# Altium schematic sheet I2C Expanders.SchDoc("I2C Expanders")
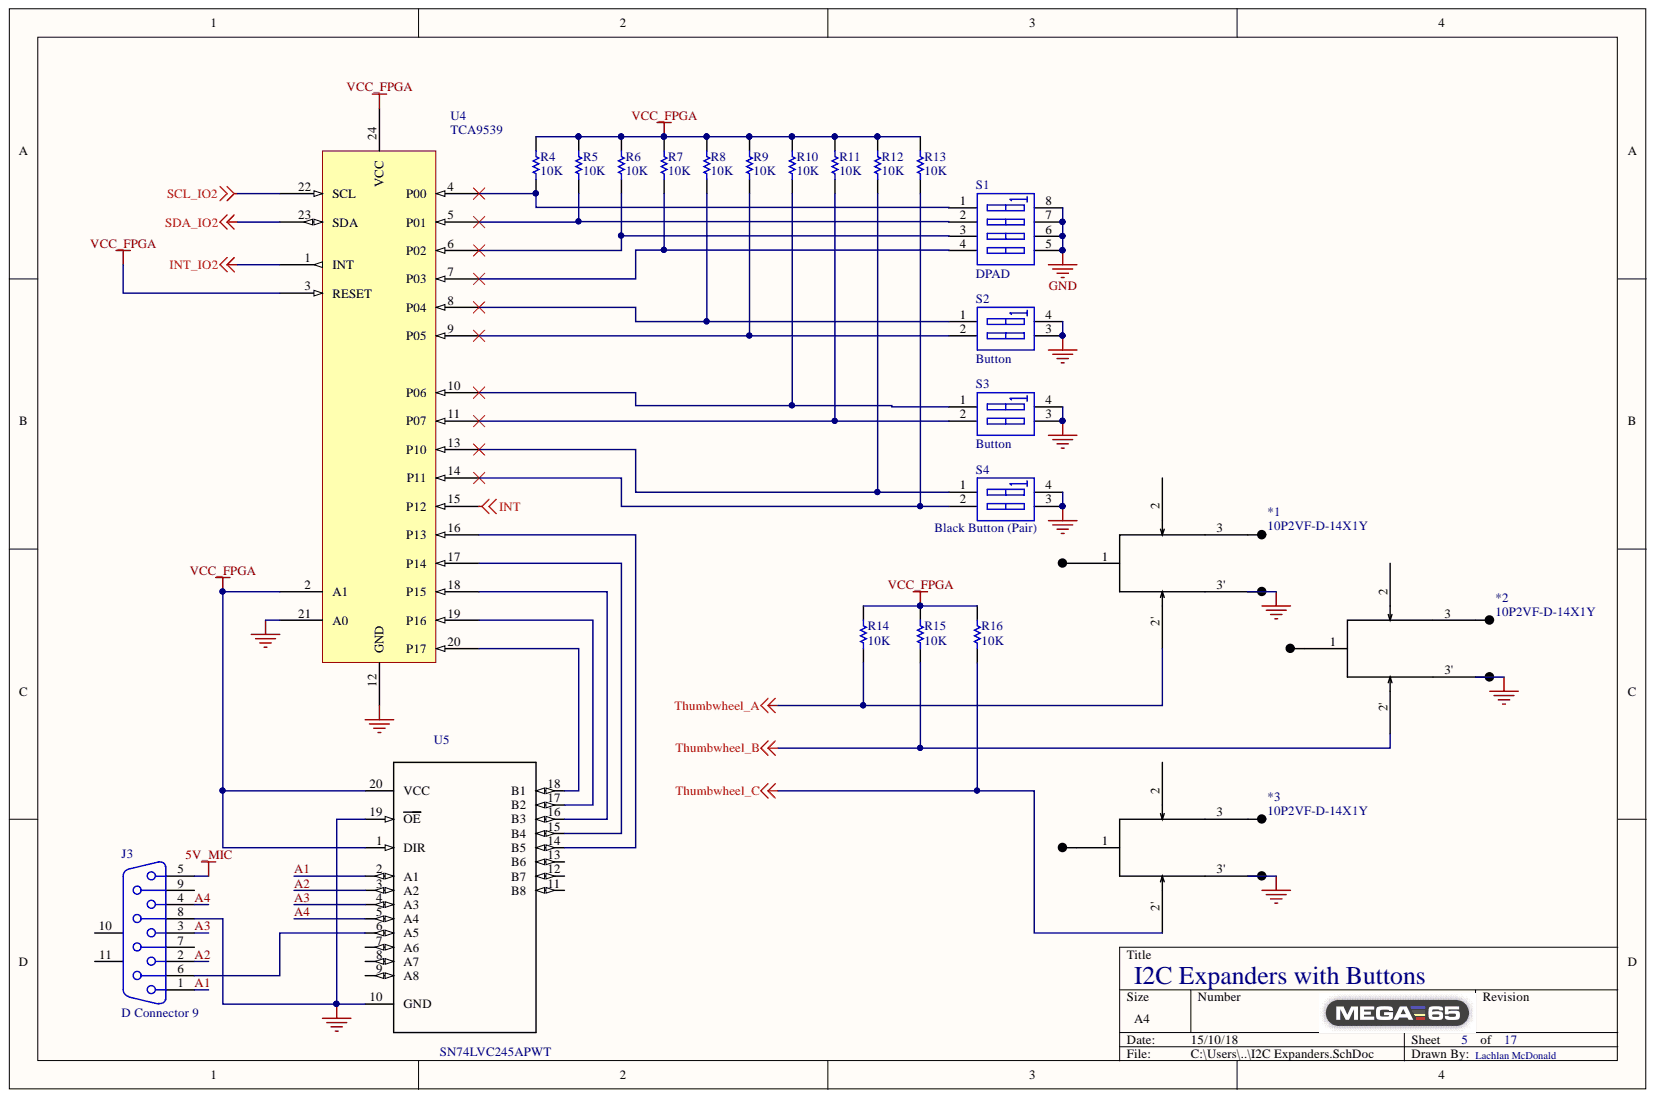
[diagram p0: I2C Expanders.SchDoc]

## Parts
*1  10P2VF-D-14X1Y  [10P2VF-D-141Y]
*2  10P2VF-D-14X1Y  [10P2VF-D-141Y]
*3  10P2VF-D-14X1Y  [10P2VF-D-141Y]
J3  D Connector 9  [DB9 Connector]
R4  10K  [RESC0805(2012)_M]
R5  10K  [RESC0805(2012)_M]
R6  10K  [RESC0805(2012)_M]
R7  10K  [RESC0805(2012)_M]
R8  10K  [RESC0805(2012)_M]
R9  10K  [RESC0805(2012)_M]
R10  10K  [RESC0805(2012)_M]
R11  10K  [RESC0805(2012)_M]
R12  10K  [RESC0805(2012)_M]
R13  10K  [RESC0805(2012)_M]
R14  10K  [RESC0805(2012)_M]
R15  10K  [RESC0805(2012)_M]
R16  10K  [RESC0805(2012)_M]
S1  DPAD  [DPAD]
S2  Button  [Button]
S3  Button  [Button]
S4  Black Button (Pair)  [Black Button]
U4  TCA9539  [PW24]
U5  SN74LVC245APWT  [SOP65P640X120-20N]

## Nets
5V_MIC: J3.5
GND: *1.3' *2.3' *3.3' J3.8 S1.5 S1.6 S1.7 S1.8 S2.3 S2.4 S3.3 S3.4 S4.3 S4.4 U4.12(GND) U4.21(A0) U5.10(GND) U5.19(OE)
INT: U4.15(P12)
INT_IO2: U4.1(INT)
SCL_IO2: U4.22(SCL)
SDA_IO2: U4.23(SDA)
Thumbwheel_A: *1.2' R14.2
Thumbwheel_B: *2.2' R15.2
Thumbwheel_C: *3.2' R16.2
VCC_FPGA: R4.1 R5.1 R6.1 R7.1 R8.1 R9.1 R10.1 R11.1 R12.1 R13.1 R14.1 R15.1 R16.1 U4.2(A1) U4.3(RESET) U4.24(VCC) U5.1(DIR) U5.20(VCC)
A1: J3.1 U5.2(A1)
A2: J3.2 U5.3(A2)
A3: J3.3 U5.4(A3)
A4: J3.4 U5.5(A4)
NetJ3_6: J3.6 U5.6(A5)
NetR4_2: R4.2 S1.1 U4.4(P00)
NetR5_2: R5.2 S1.2 U4.5(P01)
NetR6_2: R6.2 S1.3 U4.6(P02)
NetR7_2: R7.2 S1.4 U4.7(P03)
NetR8_2: R8.2 S2.1 U4.8(P04)
NetR9_2: R9.2 S2.2 U4.9(P05)
NetR10_2: R10.2 S3.1 U4.10(P06)
NetR11_2: R11.2 S3.2 U4.11(P07)
NetR12_2: R12.2 S4.1 U4.13(P10)
NetR13_2: R13.2 S4.2 U4.14(P11)
NetU4_16: U4.16(P13) U5.14(B5)
NetU4_17: U4.17(P14) U5.15(B4)
NetU4_18: U4.18(P15) U5.16(B3)
NetU4_19: U4.19(P16) U5.17(B2)
NetU4_20: U4.20(P17) U5.18(B1)

Not connected: *1 1 2 3; *2 1 2 3; *3 1 2 3; J3 7 9 10 11; U5 7(A6) 8(A7) 9(A8) 11(B8) 12(B7) 13(B6)

## Library data of the parts
J3 = D Connector 9: Receptacle Assembly, 9 Position, Right Angle; Positions: 9; PackageDocument: O; Signal Integrity: Connector; Published: 15-Jun-2001; PackageReference: 788750; Orientation: Right Angle; Library Name: Miscellaneous Connectors.IntLib; LatestRevisionNote: Re-released for DXP Platform.; LatestRevisionDate: 17-Jul-2002; Gender: Receptacle; DatasheetDocument: 1-Sep-1999; Component Kind: Standard; Publisher: Altium Limited
R4-R16 = CMP-1013-00623-1: 10K 0.125W 1% 0805 (2012 Metric)  SMD; Value: 10000.00 Ohm; Case-Metric: 2012; Case-EIA: 0805; Tolerance: 1 %; Technology: SMT; Power: 0.125 W; Library Name: Altium Content Vault; Component Kind: Standard
S1 = SW DIP-4: DIP Switch, 4 Position, SPST; Simulation: dpsw4; Published: 24-Mar-1999; PackageVersion: Dec-2002; PackageReference: SO8; PackageDescription: 8-Pin Small Outline Integrated Circuit 1.27 mm Pitch; Library Name: Miscellaneous Devices.IntLib; LatestRevisionNote: IPC-7351 Footprint Added.; LatestRevisionDate: 29-May-2009; Component Kind: Standard; Code_JEDEC: MS-012-AA; Code_IPC: SOIC127P600-8; Publisher: Altium Limited
S2-S4 = SW DIP-2: DIP Switch, 2 Position, SPST; Simulation: dpsw2; Published: 24-Mar-1999; PackageReference: DIP-4; Library Name: Miscellaneous Devices.IntLib; LatestRevisionNote: Re-released for DXP Platform.; LatestRevisionDate: 17-Jul-2002; Component Kind: Standard; Publisher: Altium Limited
U5 = SN74LVC245APWT: Octal Bus Transceiver With 3-State Outputs 20-TSSOP -40 to 125; MF: Texas Instruments; SnapEDA_Link: https://www.snapeda.com/parts/SN74LVC245APWT/Texas%20Instruments/view-part/?ref=snap; Price: 0.53 USD; Package: TSSOP-20 Texas Instruments; MP: SN74LVC245APWT; Library Name: SN74LVC245APWT.IntLib; Component Kind: Standard; Availability: Good
*1-*3 = 10P2VF-D-14X1Y: Library Name: Schlib1.SchLib; Component Kind: Standard
U4 = TCA9539: Library Name: Schlib1.SchLib; Component Kind: Standard
